# Altium schematic sheet 06 - BANK 0, SMT2 JTAG, BOOT MODE.SchDoc
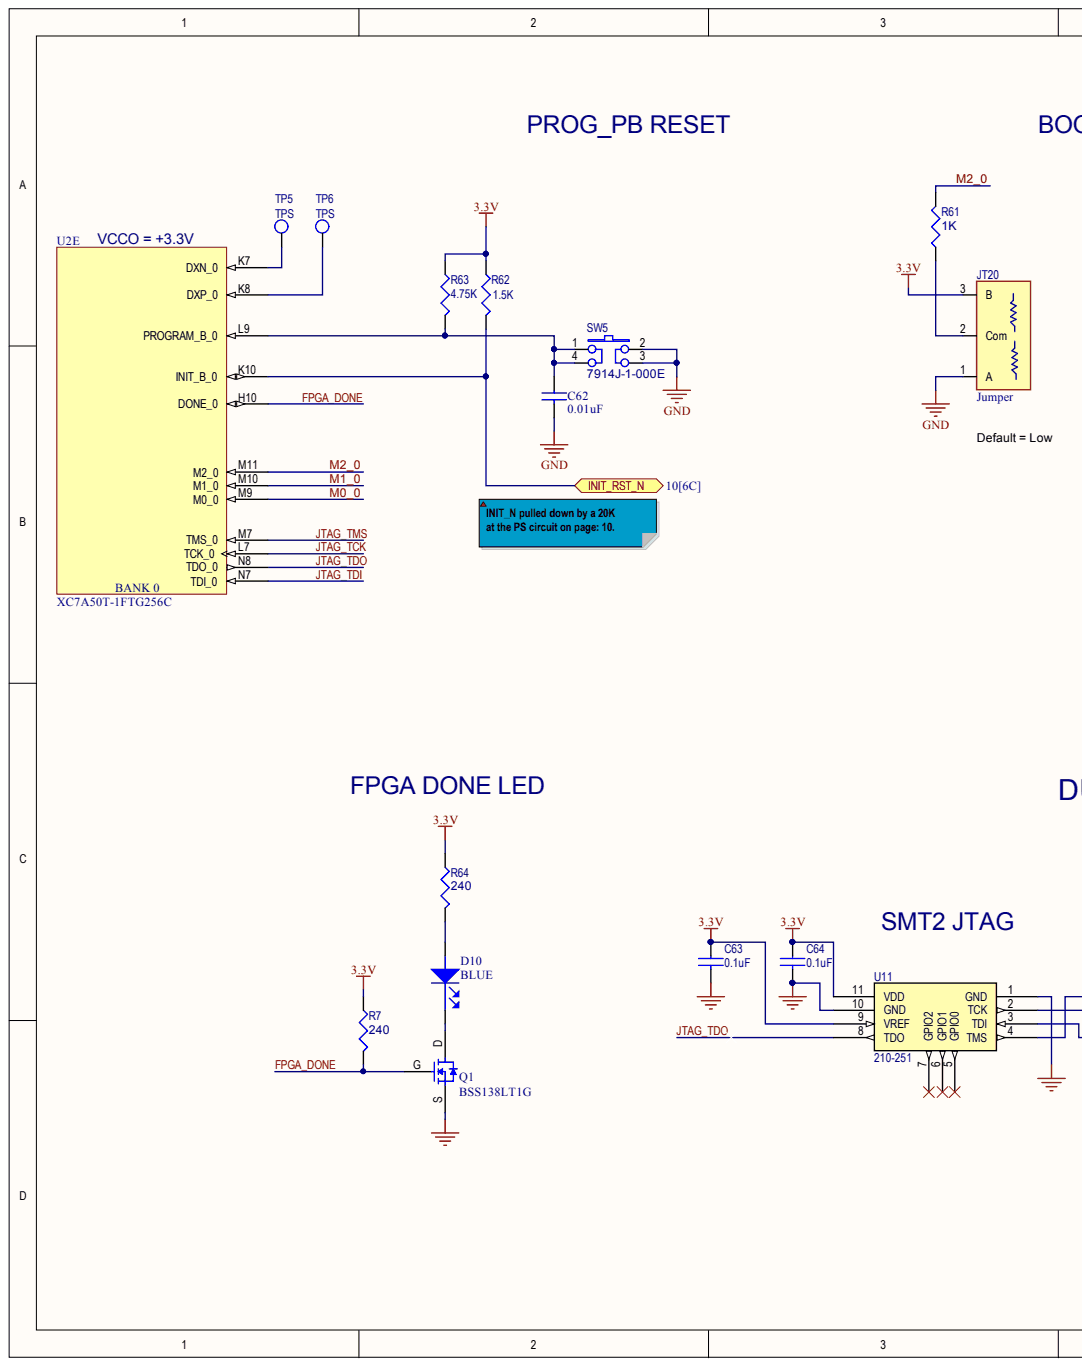
[diagram p0: 06 - BANK 0, SMT2 JTAG, BOOT MODE.SchDoc, left]
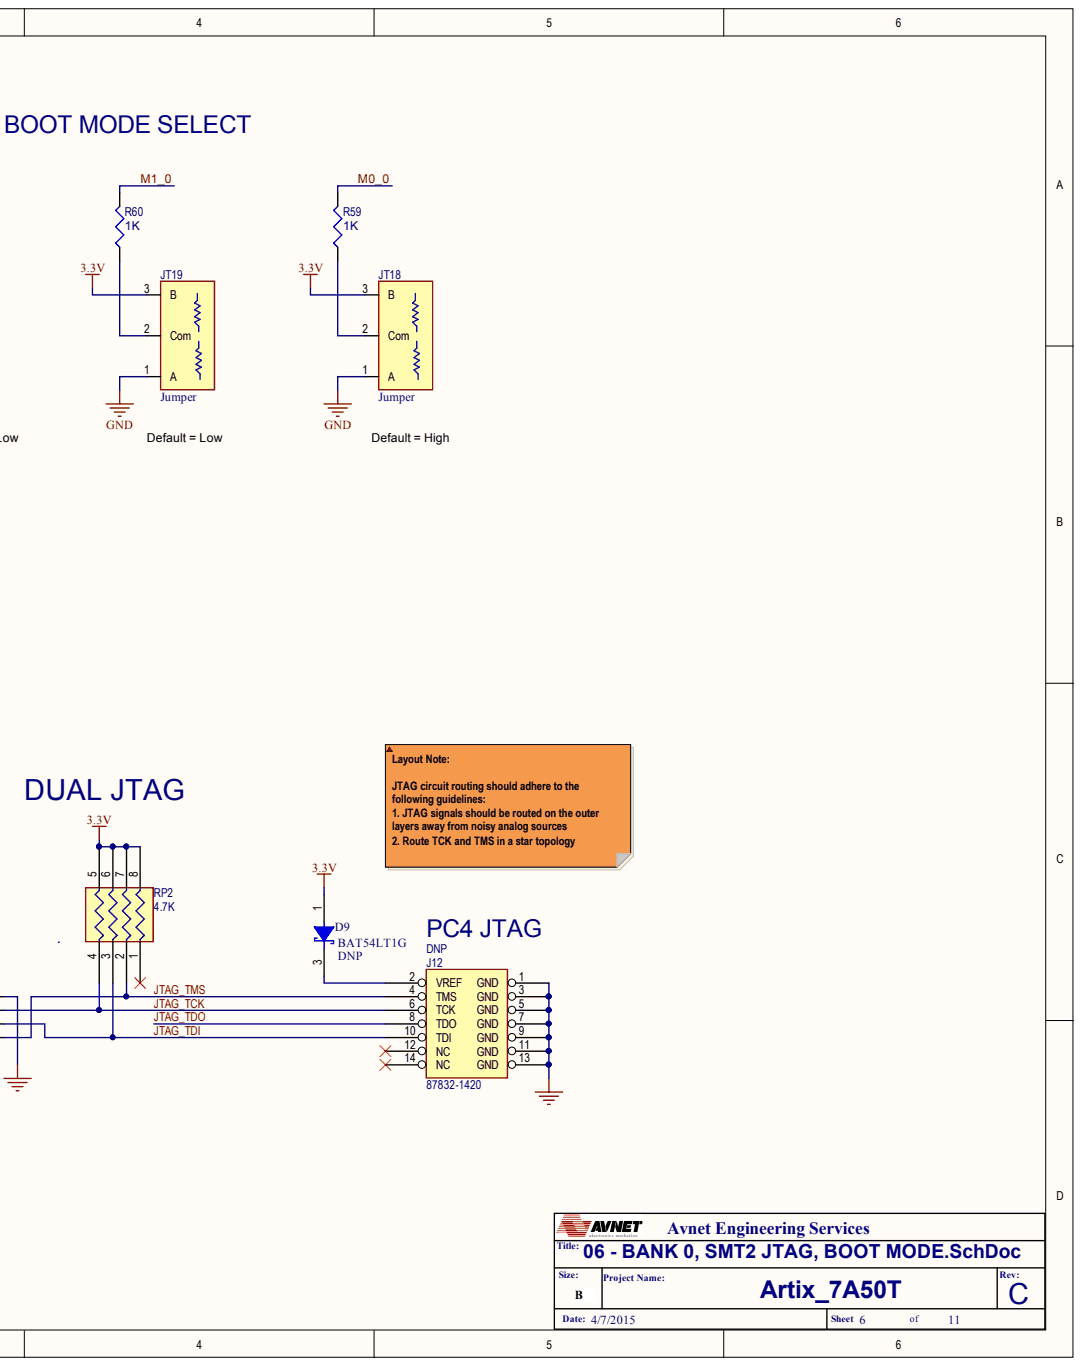
[diagram p1: 06 - BANK 0, SMT2 JTAG, BOOT MODE.SchDoc, right]

## Parts
C62  value 0.01uF  [C0201]  (hidden comment: PC003)
C63  value 0.1uF  [C0402]  (hidden comment: 6.3V)
C64  value 0.1uF  [C0402]  (hidden comment: 6.3V)
D9  BAT54LT1G  [SOT23]
D10  Device: BLUE  [LED_LITE-LTST-C191TBKT-B]  (hidden comment: DL007)
J12  87832-1420  [CON_MLX-87332-1420]
JT18  Device: Jumper  [R0402-DUAL_JMP]
JT19  Device: Jumper  [R0402-DUAL_JMP]
JT20  Device: Jumper  [R0402-DUAL_JMP]
Q1  BSS138  MFGPN: BSS138LT1G  [SOT23GSD]
R7  240  [R0402]
R59  1K  [R0402]
R60  1K  [R0402]
R61  1K  [R0402]
R62  value 1.5K  [R0402]  (hidden comment: 1K50 0402)
R63  value 4.75K  [R0402]  (hidden comment: 0402)
R64  240  [R0402]
RP2  value 4.7K  [RPACK4-LCC]  (hidden comment: CAT16-472J4LF)
SW5  Device: 7914J-1-000E  [SW_BOU-7914]  (hidden comment: ES013)
TP5  TPS  [TP24TH]
TP6  TPS  [TP24TH]
U2  MFGPN: XC7A50T-1FTG256C  [FTG256]  (hidden comment: XNLX-XC7A50T-XNLX-FTG256)  (part E)
U11  210-251  [JTAG-SMT2]

## Ports
INIT_RST_N

## Nets
3.3V: C63.1 C64.1 D9.1 JT18.3 JT19.3 JT20.3 R7.1 R62.1 R63.1 R64.1 RP2.5 RP2.6 RP2.7 RP2.8 U11.9(VREF) U11.11(VDD)
GND: C62.2 C63.2 C64.2 J12.1(GND) J12.3(GND) J12.5(GND) J12.7(GND) J12.9(GND) J12.11(GND) J12.13(GND) JT18.1 JT19.1 JT20.1 Q1.S SW5.2 SW5.3 U11.1(GND) U11.10(GND)
FPGA_DONE: Q1.G R7.2 U2.H10(DONE_0)
INIT_RST_N: R62.2 U2.K10(INIT_B_0) ; port INIT_RST_N
JTAG_TCK: J12.6(TCK) RP2.4 U2.L7(TCK_0) U11.2(TCK)
JTAG_TDI: J12.10(TDI) RP2.3 U2.N7(TDI_0) U11.3(TDI)
JTAG_TDO: J12.8(TDO) U2.N8(TDO_0) U11.8(TDO)
JTAG_TMS: J12.4(TMS) RP2.2 U2.M7(TMS_0) U11.4(TMS)
M0_0: R59.1 U2.M9(M0_0)
M1_0: R60.1 U2.M10(M1_0)
M2_0: R61.1 U2.M11(M2_0)
NetC62_1: C62.1 R63.2 SW5.1 SW5.4 U2.L9(PROGRAM_B_0)
NetD9_3: D9.3 J12.2(VREF)
NetD10_A: D10.A R64.2
NetD10_C: D10.C Q1.D
NetJT18_2: JT18.2 R59.2
NetJT19_2: JT19.2 R60.2
NetJT20_2: JT20.2 R61.2
NetTP5_1: TP5.1 U2.K7(DXN_0)
NetTP6_1: TP6.1 U2.K8(DXP_0)

Not connected: J12 12(NC) 14(NC); RP2 1; U11 5(GPIO0) 6(GPIO1) 7(GPIO2)

## Library data of the parts
C62 = AVPC-0000003: CAP SM 0.01uF 10V 10% X5R C0201; PartCode: PC; Database Table Name: Passive-Cap$; MFG Code: AVX; MFGPN: 0201ZD103KAT2A; AsyTxt: PC003; AVLNo: AVPC-0000003; Component Kind: Standard; ComponentLink1Description: Datasheet; ComponentLink2Description: MFR Website; ComponentLink2URL: www.avx.com; Device: CAP; Dielectric: X5R; ESR: CAP; PkgType: SM; RoHS: Yes; Status: Preliminary; Tol: 10%; Volt: 10V; ComponentLink1URL: C:\AVDBLIB\DATASHEETS\AVX_CX5R.pdf; Library Name: Avnet_DBlib.DbLib
C63 C64 = CAPC 0.1uF 6.3V 0402 X5R: Cap Ceramic 0.1uF 6.3V X5R 10% SMD 0402 85°C T/R; MFG Code: AVX; MFGPN: 04026D104KAT2A; Component Kind: Standard; Note: Cap Ceramic 0.1uF 6.3V X5R 10% SMD 0402 85°C T/R; Signal Integrity: CAP; Simulation: CAP; Library Name: ZYNQ_Z7045_MITX_REVA.SCHLIB
D9 = BAT54LT1G: Schottky Diodes Vf=0.35V@10mA Vr=30V; MFG#1/Part#: OnSemi: BAT54LT1G; Component Kind: Standard; DNP: DNP; Library Name: new.SchLib; MFGPN: BAT54LT1G
D10 = AVDL-0000007: LED, Blu, 468nm, Vf=3.4V, If=20mA, lv=20mcd; PartCode: DL; Database Table Name: Discrete-LED$; If: 20mA; LV: 20mcd; MFG Code: LTP; MFGPN: LTST-C191TBKT; PkgType: SM; Vf: 3.4V; AsyTxt: DL007; AVLNo: AVDL-0000007; Component Kind: Standard; ComponentLink1Description: Datasheet; Status: Preliminary; WaveLength: 468nM; ComponentLink1URL: C:\AVDBLIB\DATASHEETS\LTST-C191KRKT.pdf; Library Name: Avnet_DBlib.DbLib
J12 = Xilinx Parallel IV Connector: Conn Shrouded Header HDR 14 POS 2mm Solder ST SMD Tube; MFG Code: MLX; Component Kind: Standard; DNP: DNP; MFGPN: 87832-1420; Note: Conn Shrouded Header HDR 14 POS 2mm Solder ST SMD Tube; Library Name: ZYNQ_Z7045_MITX_REVA.SCHLIB
JT18 = AVPO-0000025: Jumper 3-pad 0402 RES-3padJPR R0402-DUAL_JMP - 0 Ohm at Pads 2-3; PartCode: PO; Database Table Name: Passive-Other$; Device Type: 3-pad 0R0 0402; MFG Code: DAL; MFGPN: CRCW04020000Z0ED: Placed at pads 2-3; PkgType: SM; Component Kind: Standard; ComponentLink1Description: Datasheet; RoHS: Yes; Status: Preliminary; ComponentLink1URL: C:\AVDBLIB\DATASHEETS\Dale_CRCW.pd; Library Name: Avnet_DBlib.DbLib
JT19 JT20 = AVPO-0000025: Jumper 3-pad 0402 RES-3padJPR R0402-DUAL_JMP - 0 Ohm at Pads 1-2; PartCode: PO; Database Table Name: Passive-Other$; Device Type: 3-pad 0R0 0402; MFG Code: DAL; MFGPN: CRCW04020000Z0ED: Placed at pads 1-2; PkgType: SM; Component Kind: Standard; ComponentLink1Description: Datasheet; RoHS: Yes; Status: Preliminary; ComponentLink1URL: C:\AVDBLIB\DATASHEETS\Dale_CRCW.pd; Library Name: Avnet_DBlib.DbLib
Q1 = MOSFET-N: MFG#1/Part#: OnSemi: BSS138LT1G; Component Kind: Standard; Description: MOSFET-N; Library Name: HKADS_Lib.IntLib
R7 R64 = AVPR-0000511: RES SM 240 62mW 0.01 R0402; Alt1MFR: NIC; PartCode: PR; Alt1PN: NRC04F2400TRF; AsyTxt: PR511; Database Table Name: Passive-Res$; MFG Code: DAL; MFGPN: CRCW0402240RFKED; Power: 0; Tol: 0.01; Availability: 1M-AV; AVLNo: AVPR-0000511; Component Kind: Standard; ComponentLink1Description: Datasheet; Cost: .01; Device: RES; PkgType: SM; Received: 0; RoHS: Yes; Size: 1.9; Status: Preliminary; Value: 240; Watt: 62mW; ComponentLink1URL: C:\AVDBLIB\DATASHEETS\Dale_CRCW.pd; Library Name: Avnet_DBlib.DbLib
R59-R61 = AVPR-0000052: RES SM 1K 62mW 0.01 R0402; PartCode: PR; AsyTxt: PR052; Database Table Name: Passive-Res$; MFG Code: DAL; MFGPN: CRCW04021K00FKED; Tol: 0.01; AVLNo: AVPR-0000052; Component Kind: Standard; ComponentLink1Description: Datasheet; Device: RES; PkgType: SM; RoHS: Yes; Status: Preliminary; Value: 1K; Watt: 62mW; ComponentLink1URL: C:\AVDBLIB\DATASHEETS\Dale_CRCW.pd; Library Name: Avnet_DBlib.DbLib
R62 = RESC 1K50 0402: Chip Resistor 0402 1.50K 1/16W 1%; AES Part #: AVPR-0000056; Preferred Part #: DAL CRCW04021K50FKED; MFGPN: CRCW04021K50FKED; Component Kind: Standard; Library Name: Passive.SchLib; Signal Integrity: RES; Simulation: RES; Status: Preliminary
R63 = RESC 4K75 0402: Res Thick Film 0402 4.75K Ohm 1% 1/16W ±100ppm/°C Molded SMD SMD Paper T/R; MFG Code: DAL; MFGPN: CRCW04024K75FKED; Component Kind: Standard; Note: Res Thick Film 0402 4.75K Ohm 1% 1/16W ±100ppm/°C Molded SMD SMD Paper T/R; Signal Integrity: RES; Simulation: RES; Library Name: ZYNQ_Z7045_MITX_REVA.SCHLIB
RP2 = RESCAXE 4.7K: Res Thick Film Array 4.7K Ohm 5% ISOL Molded 8-Pin 1206 Concave SMD Paper T/R; MFG Code: BNS; Component Kind: Standard; MFGPN: CAT16-472J4LF; Note: Res Thick Film Array 4.7K Ohm 5% ISOL Molded 8-Pin 1206 Concave SMD Paper T/R; Library Name: ZYNQ_Z7045_MITX_REVA.SCHLIB
SW5 = AVES-0000013: Switch Momentary SM PB-SPST Push Button NO  1ch 16VDC 100mA  SW-PB-SPST SW_BOU-7914; PartCode: ES; Database Table Name: EM-Switch$; MFG Code: BNS; AsyTxt: ES013; AVLNo: AVES-0000013; Component Kind: Standard; ComponentLink1Description: Datasheet; Current: 100mA; Default: NO; Device Sub Type: PB-SPST; Device Type: Switch Momentary; MFGPN: 7914J-1-000E; PkgType: SM; Ports/Channels: 1ch; RoHS: Yes; Status: Preliminary; Technology: Push Button; Volts: 16VDC; ComponentLink1URL: C:\AVDBLIB\DATASHEETS\SW7914.pdf; Library Name: Avnet_DBlib.DbLib
TP5 TP6 = AVPO-0000039: Test Point 24 mil pad, round, 10 mil hole, small symbol TPS TP24TH; MFG Code: None; Component Kind: Standard; DNP: DNP; MFGPN: None; Library Name: ZYNQ_Z7045_MITX_REVA.SCHLIB
U2 = XNLX-XC7A50T-XNLX-FTG256: PKG: FBGA256; Component Kind: Standard; Library Name: Artix_7A50_1.SCHLIB
U11 = JTAG-SMT2: JTAG SMT2 HIGH SPEED PROGRAMMING MODULE; MFG Code: Digilent; Component Kind: Standard; MFGPN: 210-251; Note: JTAG SMT2 HIGH SPEED PROGRAMMING MODULE; Library Name: ZYNQ_Z7045_MITX_REVA.SCHLIB
